# Altium schematic sheet 1-MCU.SchDoc(1-MCU)
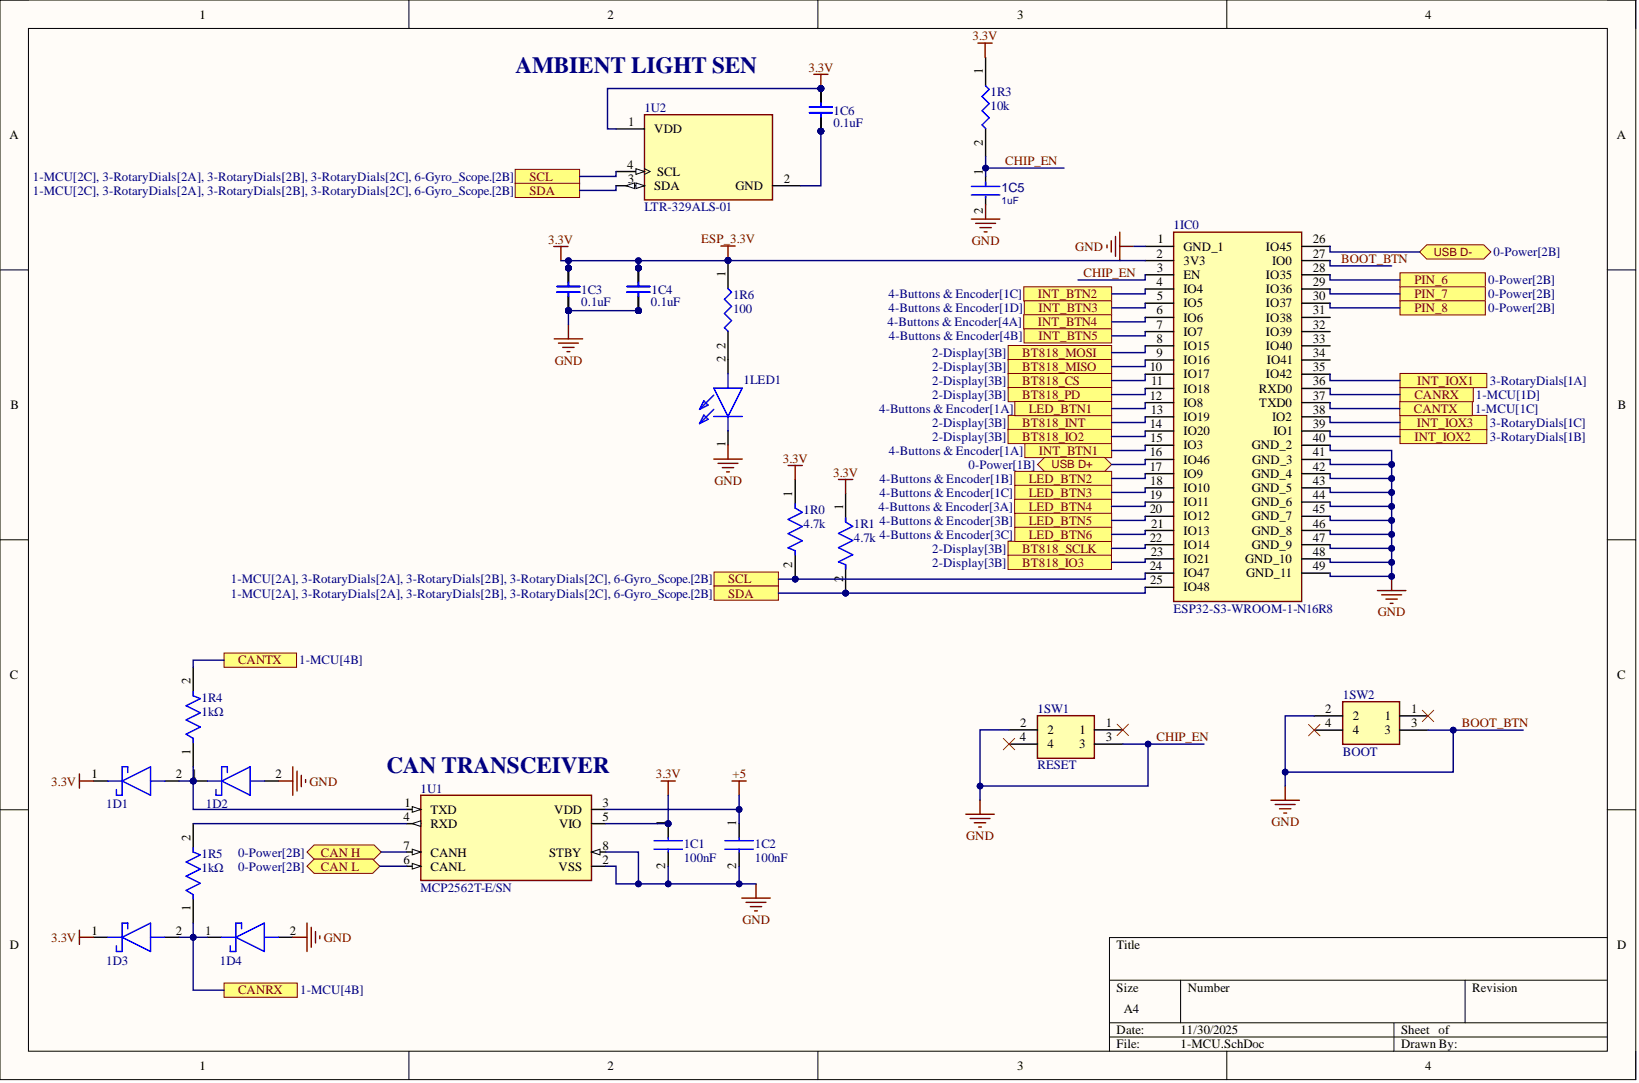
[diagram p0: 1-MCU.SchDoc]

## Parts
1C1  100nF
1C2  100nF
1C3  0.1uF
1C4  0.1uF
1C5  1uF
1C6  0.1uF
1D1  SL115B-TP  (value not drawn)
1D2  SL115B-TP  (value not drawn)
1D3  SL115B-TP  (value not drawn)
1D4  SL115B-TP  (value not drawn)
1IC0  ESP32-S3-WROOM-1-N16R8
1LED1  LS_Q976-NR-1-0-20-R18  (value not drawn)
1R0  4.7k
1R1  4.7k
1R3  10k
1R4  1kΩ
1R5  1kΩ
1R6  100
1SW1  RESET
1SW2  BOOT
1U1  MCP2562T-E/SN
1U2  LTR-329ALS-01

## Ports
BT818_CS, BT818_INT, BT818_IO2, BT818_IO3, BT818_MISO, BT818_MOSI, BT818_PD, BT818_SCLK, CAN H, CAN L, CANRX, CANTX, INT_BTN1, INT_BTN2, INT_BTN3, INT_BTN4, INT_BTN5, INT_IOX1, INT_IOX2, INT_IOX3, LED_BTN1, LED_BTN2, LED_BTN3, LED_BTN4, LED_BTN5, LED_BTN6, PIN_6, PIN_7, PIN_8, SCL, SDA, USB D+, USB D-

## Nets
3.3V: 1C1.1 1C3.1 1C4.1 1C6.1 1D1.1 1D3.1 1IC0.2(3V3) 1R0.1 1R1.1 1R6.1 1U1.5(VIO) 1U2.1(VDD)
+5: 1C2.1 1U1.3(VDD)
BOOT_BTN: 1C1.2 1C2.2 1C3.2 1C4.2 1C5.1 1C5.2 1D2.2 1D4.2 1IC0.1(GND_1) 1IC0.3(EN) 1IC0.27(IO0) 1IC0.40(GND_2) 1IC0.41(GND_3) 1IC0.42(GND_4) 1IC0.43(GND_5) 1IC0.44(GND_6) 1IC0.45(GND_7) 1IC0.46(GND_8) 1IC0.47(GND_9) 1IC0.48(GND_10) 1IC0.49(GND_11) 1LED1.1 1R3.2 1SW1.2 1SW1.3 1SW2.2 1SW2.3 1U1.2(VSS) 1U1.8(STBY)
BT818_CS: 1IC0.10(IO17) ; port BT818_CS
BT818_INT: 1IC0.13(IO19) ; port BT818_INT
BT818_IO2: 1IC0.14(IO20) ; port BT818_IO2
BT818_IO3: 1IC0.23(IO21) ; port BT818_IO3
BT818_MISO: 1IC0.9(IO16) ; port BT818_MISO
BT818_MOSI: 1IC0.8(IO15) ; port BT818_MOSI
BT818_PD: 1IC0.11(IO18) ; port BT818_PD
BT818_SCLK: 1IC0.22(IO14) ; port BT818_SCLK
CAN H: 1U1.7(CANH) ; port CAN H
CAN L: 1U1.6(CANL) ; port CAN L
CANRX: 1D3.2 1D4.1 1IC0.36(RXD0) 1R5.1 ; port CANRX
CANTX: 1IC0.37(TXD0) 1R4.2 ; port CANTX
INT_BTN1: 1IC0.15(IO3) ; port INT_BTN1
INT_BTN2: 1IC0.4(IO4) ; port INT_BTN2
INT_BTN3: 1IC0.5(IO5) ; port INT_BTN3
INT_BTN4: 1IC0.6(IO6) ; port INT_BTN4
INT_BTN5: 1IC0.7(IO7) ; port INT_BTN5
INT_IOX1: 1IC0.35(IO42) ; port INT_IOX1
INT_IOX2: 1IC0.39(IO1) ; port INT_IOX2
INT_IOX3: 1IC0.38(IO2) ; port INT_IOX3
LED_BTN1: 1IC0.12(IO8) ; port LED_BTN1
LED_BTN2: 1IC0.17(IO9) ; port LED_BTN2
LED_BTN3: 1IC0.18(IO10) ; port LED_BTN3
LED_BTN4: 1IC0.19(IO11) ; port LED_BTN4
LED_BTN5: 1IC0.20(IO12) ; port LED_BTN5
LED_BTN6: 1IC0.21(IO13) ; port LED_BTN6
PIN_6: 1IC0.28(IO35) ; port PIN_6
PIN_7: 1IC0.29(IO36) ; port PIN_7
PIN_8: 1IC0.30(IO37) ; port PIN_8
SCL: 1IC0.24(IO47) 1R0.2 1U2.4(SCL) ; port SCL
SDA: 1IC0.25(IO48) 1R1.2 1U2.3(SDA) ; port SDA
Net1C6_2: 1C6.2 1U2.2(GND)
Net1D1_2: 1D1.2 1D2.1 1R4.1 1U1.1(TXD)
Net1IC0_16: 1IC0.16(IO46)
Net1IC0_26: 1IC0.26(IO45)
Net1LED1_2: 1LED1.2 1R6.2
Net1R5_2: 1R5.2 1U1.4(RXD)

Not connected: 1IC0 31(IO38) 32(IO39) 33(IO40) 34(IO41); 1R3 1; 1SW1 1 4; 1SW2 1 4

## Library data of the parts
1C1 1C2 = CL10B104KA8NNNC: Manufacturer_Name: SAMSUNG; Arrow Part Number: CL10B104KA8NNNC; Arrow Price/Stock: https://www.arrow.com/en/products/cl10b104ka8nnnc/samsung-electro-mechanics?utm_currency=USD&region=europe; Component Kind: Standard; Datasheet Link: http://media.digikey.com/pdf/Data%20Sheets/Samsung%20PDFs/CL_Series_MLCC_ds.pdf; Description: CL10B104KA8NNNC; Height: 0.9mm; Manufacturer_Part_Number: CL10B104KA8NNNC; Mouser Part Number: 187-CL10B104KA8NNNC; Mouser Price/Stock: https://www.mouser.co.uk/ProductDetail/Samsung-Electro-Mechanics/CL10B104KA8NNNC?qs=349EhDEZ59rmu3pGeZXx0A%3D%3D
1C3 1C4 1C6 = CC0603KRX7R7BB104: Ceramic Capacitor, Multilayer, Ceramic, 16V, 10% +Tol, 10% -Tol, X7R, 15% TC, 0.1uF, Surface Mount, 0603; Manufacturer: Yageo; Multilayer: Yes; Value: 100nF; Capacitor Type: Ceramic; Case/Package: 0603; Category: Ceramic Capacitors; Component Kind: Standard; ComponentLink1Description: https://datasheet.ciiva.com:443/pdfs/VipMasterIC/IC/YAGO/YAGO-S-A0003557223/YAGO-S-A0003557223-1.pdf?src-supplier=IHS+Markit; ComponentLink1URL: https://datasheet.ciiva.com:443/pdfs/VipMasterIC/IC/YAGO/YAGO-S-A0003557223/YAGO-S-A0003557223-1.pdf?src-supplier=IHS+Markit; ComponentLink2Description: https://datasheet.ciiva.com/11786/0900766b80d84f1d-11786077.pdf?src-supplier=Rs; ComponentLink2URL: https://datasheet.ciiva.com/11786/0900766b80d84f1d-11786077.pdf?src-supplier=Rs; ComponentLink3Description: https://datasheet.ciiva.com/46430/1734573-46430156.pdf?src-supplier=Element14; ComponentLink3URL: https://datasheet.ciiva.com/46430/1734573-46430156.pdf?src-supplier=Element14; ComponentLink4Description: https://datasheet.ciiva.com/8033/1734573-8033797.pdf?src-supplier=Element14; ComponentLink4URL: https://datasheet.ciiva.com/8033/1734573-8033797.pdf?src-supplier=Element14; ComponentLink5Description: https://datasheet.ciiva.com/8033/70765-8033799.pdf?src-supplier=Element14; ComponentLink5URL: https://datasheet.ciiva.com/8033/70765-8033799.pdf?src-supplier=Element14; ComponentLink6Description: https://datasheet.ciiva.com/28450/1489800-28450552.pdf?src-supplier=Element14; ComponentLink6URL: https://datasheet.ciiva.com/28450/1489800-28450552.pdf?src-supplier=Element14; ComponentLink7Description: https://datasheet.ciiva.com/144/mlccorderingcode-144182.pdf?src-supplier=Digikey; ComponentLink7URL: https://datasheet.ciiva.com/144/mlccorderingcode-144182.pdf?src-supplier=Digikey; ComponentLink8Description: https://datasheet.ciiva.com/144/mlccorderingcode-144183.pdf?src-supplier=Digikey; ComponentLink8URL: https://datasheet.ciiva.com/144/mlccorderingcode-144183.pdf?src-supplier=Digikey; ComponentLink9Description: https://datasheet.ciiva.com/144/mlccorderingcode-144184.pdf?src-supplier=Digikey; ComponentLink9URL: https://datasheet.ciiva.com/144/mlccorderingcode-144184.pdf?src-supplier=Digikey; ComponentLink10Description: https://datasheet.ciiva.com/11464/upy-gphc-x7r-6-3v-to-50v-8-11464735.pdf?src-supplier=Avnet; ComponentLink10URL: https://datasheet.ciiva.com/11464/upy-gphc-x7r-6-3v-to-50v-8-11464735.pdf?src-supplier=Avnet; ComponentLink11Description: https://datasheet.ciiva.com/13395/upy-gphc-x7r-6-3v-to-50v-8-13395674.pdf?src-supplier=Mouser; ComponentLink11URL: https://datasheet.ciiva.com/13395/upy-gphc-x7r-6-3v-to-50v-8-13395674.pdf?src-supplier=Mouser; ComponentLink12Description: https://datasheet.ciiva.com/7978/1489800-7978141.pdf?src-supplier=Element14; ComponentLink12URL: https://datasheet.ciiva.com/7978/1489800-7978141.pdf?src-supplier=Element14; ComponentLink13Description: https://datasheet.ciiva.com/15165/getdatasheetpartid-63554-15165387.pdf?src-supplier=Verical; ComponentLink13URL: https://datasheet.ciiva.com/15165/getdatasheetpartid-63554-15165387.pdf?src-supplier=Verical; Dielectric Material: Ceramic; Height: 0.8mm; JESD-609 Code: e3; LCSC Part Number: C108079; Length: 1.6mm; Library Name: Schlib.SchLib; Manufacturer Part Number: CC0603KRX7R7BB104; Max Operating Temperature: 125°C; Min Operating Temperature: -55°C; Mounting Technology: Surface Mount; Package Shape: Rectangular; Package Style: SMTMeter; Packaging: Tape and Reel; Pins: 2; Pricing: 1=0.08, 10=0.022, 100=0.0144, 1000=0.0096 (USD); Rated DC Voltage (URdc): 16V; Reach SVHC Compliant: Yes; RoHS: Yes; RoHS Compliant: Yes; Stock: 7921114; Supplier: Digikey; Supplier Part Number: 311-1088-1-ND; Temperature Characteristics Code: X7R; Terminal Finish: Nickel; Termination: Wraparound; Tolerance  (fill in as +-): 10%; Tolerance (fill in as +-): 10%; Width: 0.8mm
1C5 = CMP-2000-05447-3: UMK107BJ105KA-T; Dielectric: X5R; Tolerance: 10%; Voltage Rating: 50V; Capacitance: 1uF; Case Code (Imperial): 0603; Case Code (Metric): 1608; Case/Package: 0603; Component Kind: Standard; Construction: Flat; Contact Plating: Nickel; Depth: 0.8mm; Halogen Free: Halogen Free; Height: 0.8mm; Length: 1.6mm; Library Name: Altium Content Vault; Max Operating Temperature: 85°C; Min Operating Temperature: -55°C; Mount: Surface Mount; Number of Pins: 2; Package Quantity: 1; Packaging: Tape and Reel; Radiation Hardening: No; RoHS Compliant: Yes; Series: M; Termination: SMD/SMT; Thickness: 0.035inch; Voltage Rating (DC): 50V; Width: 0.031inch
1D1-1D4 = SL115B-TP: Schottky Diode; Manufacturer_Name: MCC; Component Kind: Standard; Datasheet Link: https://mccsemi.com/pdf/Products/SL115B(SMB).pdf; Height: 2.62mm; Manufacturer_Part_Number: SL115B-TP
1IC0 = ESP32-S3-WROOM-1-N16R8: Integrated Circuit; Manufacturer_Name: Espressif Systems; Component Kind: Standard; Datasheet Link: https://www.espressif.com/sites/default/files/documentation/esp32-s3-wroom-1_wroom-1u_datasheet_en.pdf; Height: 3.25mm; Library Name: SamacSys.SchLib; Manufacturer_Part_Number: ESP32-S3-WROOM-1-N16R8; Mouser Part Number: 356-ESP32S3WRM1N16R8; Mouser Price/Stock: https://www.mouser.co.uk/ProductDetail/Espressif-Systems/ESP32-S3-WROOM-1-N16R8?qs=Li%252BoUPsLEnvQc9gW6AMhZg%3D%3D
1LED1 = LS_Q976-NR-1-0-20-R18: LED; Manufacturer_Name: ams OSRAM; Manufacturer_Part_Number: LS Q976-NR-1-0-20-R18; Arrow Part Number: LS Q976-NR-1-0-20-R18; Arrow Price/Stock: https://www.arrow.com/en/products/lsq976-nr-1-0-20-r18/osram-opto-semiconductors; Component Kind: Standard; Datasheet Link: https://dammedia.osram.info/media/resource/hires/osram-dam-6494322/LS%20Q976_EN.pdf; Height: 0.84mm; Mouser Part Number: 720-LSQ976-NR-1; Mouser Price/Stock: https://www.mouser.co.uk/ProductDetail/ams-OSRAM/LS-Q976-NR-1-0-20-R18?qs=p6VZ%252BklCkRTtkQHmJ7k3Og%3D%3D
1R0 1R1 = CMP-2001-04476-2: RES SMD 4.7K OHM 5% 1/8W 0805; Manufacturer: Yageo; Manufacturer Part Number: AC0805JR-074K7L; Automotive Grade: Grade 0; Resistance: 4.7KΩ; Tolerance: 0.05; Value: 4.7KΩ; Voltage Rating: 150V; Automotive: Yes; Category: Res; Component Kind: Standard; ComponentLink2Description: Datasheet; ComponentLink2URL: http://www.yageo.com/documents/recent/PYu-AC_51_RoHS_L_6.pdf; Composition: Thick Film; Device Class L1: Passive Components; Device Class L2: Resistors; Device Class L3: Chip SMD Resistors; Digikey Description: RES SMD 4.7K OHM 5% 1/8W 0805; Footprint Url: http://www.yageo.com/exep/pages/download/literatures/PYu-R_Mount_10.pdf; Height: 0.6mm; Ipc Land Pattern Name: RESC200125X50; Lead Free: Yes; Library Name: Altium Content Vault; Mouser Description: Thick Film Resistors - SMD 1/8W 4.7K ohm 5% AEC-Q200; Octopart: https://octopart.com/ac0805jr-074k7l-yageo-26638632; Package: AC0805; Power Rating: 1/8W; RoHS: TRUE; Series: AC; Temperature: -55°C to +155°C; Temperature Range High: +155°C; Temperature Range Low: -55°C
1R3 = ERJ-6GEYJ103V: Resistor; Manufacturer_Name: Panasonic; Arrow Part Number: ERJ-6GEYJ103V; Arrow Price/Stock: https://www.arrow.com/en/products/erj6geyj103v/panasonic?region=nac; Component Kind: Standard; Datasheet Link: https://datasheet.datasheetarchive.com/originals/distributors/DKDS40/DSANUWW0010911.pdf; Height: 0.7mm; Library Name: SamacSys.SchLib; Manufacturer_Part_Number: ERJ-6GEYJ103V; Mouser Part Number: 667-ERJ-6GEYJ103V; Mouser Price/Stock: https://www.mouser.co.uk/ProductDetail/Panasonic/ERJ-6GEYJ103V?qs=tQNqqtTOejK5rw%252BRxJVxUA%3D%3D
1R4 1R5 = CMP-2001-03210-2: 1kΩ ±0.5% 0.1W 1/10W Chip Resistor 0805 (2012 Metric) Thin Film; Max Power Dissipation: 100mW; Resistance: 1kR; Temperature Coefficient: 25ppm/°C; Voltage Rating: 100V; Case Code (Imperial): 0805; Case Code (Metric): 2012; Case/Package: 0805; Component Kind: Standard; Depth: 1.25mm; Height: 0.4mm; Lead Free: Lead Free; Length: 2mm; Library Name: Altium Content Vault; Max Operating Temperature: 125°C; Min Operating Temperature: -55°C; Number of Terminations: 2; Package Quantity: 1; Packaging: Tape and Reel; Power Rating: 100mW; Resistance Tolerance: 0.5%; Resistor Type: Thin Film; RoHS Compliant: Yes; Series: RR; Size Code: 0805; Technology: THIN FILM; Termination: SMD/SMT; Tolerance: 0.5%; Voltage Rating (DC): 100V; Width: 1.25mm
1R6 = CRCW0805100RFKEC: Resistor; Manufacturer_Name: Vishay; Arrow Part Number: CRCW0805100RFKEC; Arrow Price/Stock: https://www.arrow.com/en/products/crcw0805100rfkec/vishay?region=nac; Component Kind: Standard; Datasheet Link: http://www.vishay.com/docs/20035/dcrcwe3.pdf; Height: 0.5mm; Library Name: SamacSys.SchLib; Manufacturer_Part_Number: CRCW0805100RFKEC; Mouser Part Number: 71-CRCW0805100RFKEC; Mouser Price/Stock: https://www.mouser.co.uk/ProductDetail/Vishay-Dale/CRCW0805100RFKEC?qs=waxnFHLl2hAP37ywEEHvOA%3D%3D
1SW1 = TL3365AF180QG: Switch; Manufacturer_Name: E-Switch; Component Kind: Standard; Datasheet Link: https://configured-product-images.s3.amazonaws.com/2D/specs/TL3365AF180QG.pdf; Height: 2.75mm; Manufacturer_Part_Number: TL3365AF180QG; Mouser Part Number: 612-TL3365AF180QG; Mouser Price/Stock: https://www.mouser.co.uk/ProductDetail/E-Switch/TL3365AF180QG?qs=xQzBpF%2FAFTo%252BA4IL7l2Rvw%3D%3D
1SW2 = TL3365AF180QG: Manufacturer_Name: E-Switch; Component Kind: Standard; Datasheet Link: https://configured-product-images.s3.amazonaws.com/2D/specs/TL3365AF180QG.pdf; Description: TL3365AF180QG; Height: 2.75mm; Manufacturer_Part_Number: TL3365AF180QG; Mouser Part Number: 612-TL3365AF180QG; Mouser Price/Stock: https://www.mouser.co.uk/ProductDetail/E-Switch/TL3365AF180QG?qs=xQzBpF%2FAFTo%252BA4IL7l2Rvw%3D%3D
1U1 = CMP-229-000000-1: 1/1 Transceiver Half CANbus 8-SOIC; MF: Microchip; Component Kind: Standard; Component Type: Standard; Design Item ID: MCP2562T-E/SN; Digi-Key_Part_Number: MCP2562T-E/SNCT-ND; Digi-Key_Purchase_URL: https://www.digikey.com/product-detail/en/microchip-technology/MCP2562T-E-SN/MCP2562T-E-SNCT-ND/5011025?utm_source=snapeda&utm_medium=aggregator&utm_campaign=symbol; MP: MCP2562T-E/SN; Package: SO-8 Microchip; Pin Count: 8; SnapEDA_Link: https://www.snapeda.com/parts/MCP2562T-E/SN/Microchip/view-part/554377/?ref=snap; Source: SnapEDAsch.SchLib; Library Name: Western Formula Racing
1U2 = CMP-22027-000001-1: SENSOR OPT AMBIENT 4DFN; Output Type: I2C; Case/Package: SMD/SMT; Component Kind: Standard; Library Name: Altium Content Vault; Max Operating Temperature: 70°C; Min Operating Temperature: -30°C; Mount: Surface Mount; Number of Pins: 4; Packaging: Tape and Reel
